# Altium schematic sheet ble_em9301.SchDoc
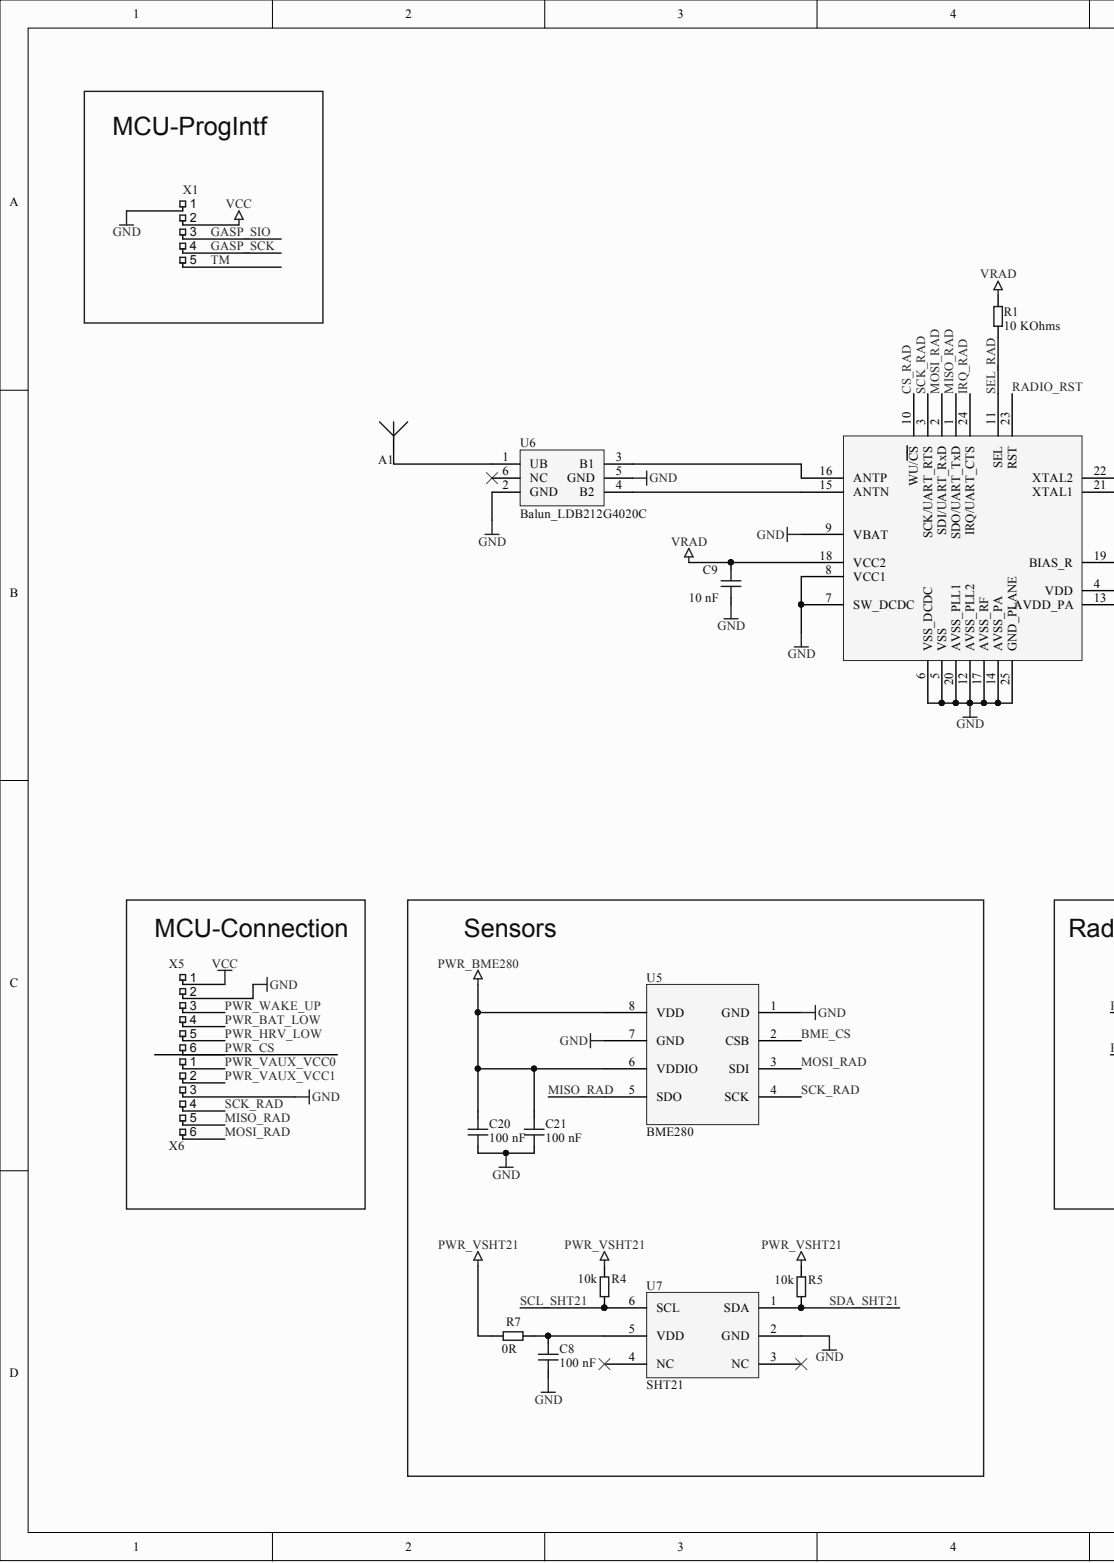
[diagram p0: ble_em9301.SchDoc, left]
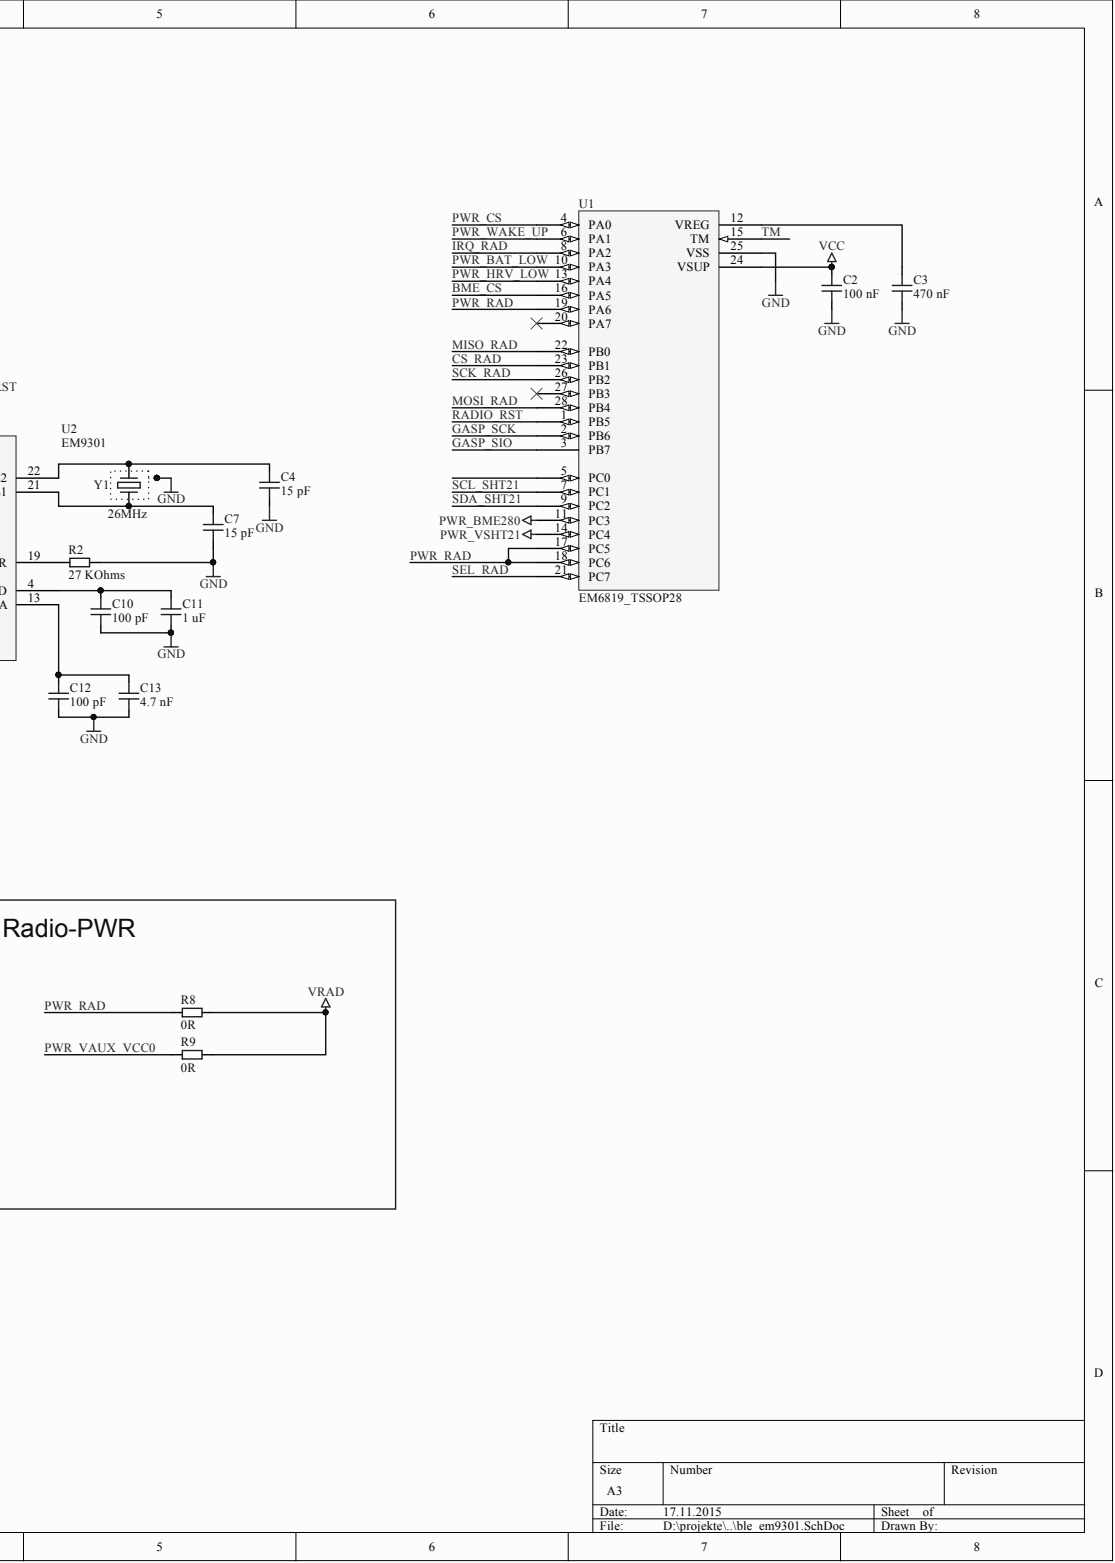
[diagram p1: ble_em9301.SchDoc, right]

## Parts
A1  Ant  [ANT AN2051]  (value not drawn)
C2  value 100 nF  [Chip_1005L]  (hidden comment: Cap)
C3  value 470 nF  [Chip_1005L]  (hidden comment: Cap)
C4  value 15 pF  [Chip_1005L]  (hidden comment: Cap)
C7  value 15 pF  [Chip_1005L]  (hidden comment: Cap)
C8  value 100 nF  [Chip_1005L]  (hidden comment: Cap)
C9  value 10 nF  [Chip_1005L]  (hidden comment: Cap)
C10  value 100 pF  [Chip_1005L]  (hidden comment: Cap)
C11  value 1 uF  [Chip_1005L]  (hidden comment: Cap)
C12  value 100 pF  [Chip_1005L]  (hidden comment: Cap)
C13  value 4.7 nF  [Chip_1005L]  (hidden comment: Cap)
C20  value 100 nF  [Chip_1005L]  (hidden comment: Cap)
C21  value 100 nF  [Chip_1005L]  (hidden comment: Cap)
R1  R  value 10 KOhms  [Chip_1005L]
R2  R  value 27 KOhms  [Chip_1005L]
R4  R  value 10k  [Chip_1005L]
R5  R  value 10k  [Chip_1005L]
R7  R  value 0R  [Chip_0603L]
R8  R  value 0R  [Chip_0603L]
R9  R  value 0R  [Chip_0603L]
U1  EM6819_TSSOP28  [TSSOP28]
U2  EM9301  [QFN-24_5x5]
U5  BME280  [SON65P250X94-8N]
U6  Balun_LDB212G4020C  [BALUN_0802]
U7  SHT21  [SHT21/x]
X1  TEST  [HEADER 1x5 50mil F]  (value not drawn)
X5  TEST  [HEADER 1x6 50mil]  (value not drawn)
X6  TEST  [HEADER 1x6 50mil]  (value not drawn)
Y1  value 26MHz  [XTAL ABM8]  (hidden comment: XTAL)

## Nets
GND: C2.1 C3.1 C4.1 C7.1 C8.1 C9.1 C10.1 C11.1 C12.1 C13.1 C20.1 C21.1 R2.2 U1.25(VSS) U2.5(VSS) U2.6(VSS_DCDC) U2.7(SW_DCDC) U2.8(VCC1) U2.9(VBAT) U2.12(AVSS_PLL2) U2.14(AVSS_PA) U2.17(AVSS_RF) U2.20(AVSS_PLL1) U2.25(GND_PLANE) U5.1(GND) U5.7(GND) U6.2(GND) U6.5(GND) U7.2(GND) X1.1 X5.2 X6.3 Y1.2 Y1.4
PWR_BME280: C20.2 C21.2 U1.11(PC3) U5.6(VDDIO) U5.8(VDD)
PWR_VSHT21: R4.2 R5.1 R7.1 U1.14(PC4)
VCC: C2.2 U1.24(VSUP) X1.2 X5.1
VRAD: C9.2 R1.2 R8.2 R9.2 U2.18(VCC2)
BME_CS: U1.16(PA5) U5.2(CSB)
CS_RAD: U1.23(PB1) U2.10(WU/CS)
GASP_SCK: U1.2(PB6) X1.4
GASP_SIO: U1.3(PB7) X1.3
IRQ_RAD: U1.8(PA2) U2.24(IRQ/UART_CTS)
MISO_RAD: U1.22(PB0) U2.1(SDO/UART_TxD) U5.5(SDO) X6.5
MOSI_RAD: U1.28(PB4) U2.2(SDI/UART_RxD) U5.3(SDI) X6.6
PWR_BAT_LOW: U1.10(PA3) X5.4
PWR_CS: U1.4(PA0) X5.6
PWR_HRV_LOW: U1.13(PA4) X5.5
PWR_RAD: R8.1 U1.17(PC5) U1.18(PC6) U1.19(PA6)
PWR_VAUX_VCC0: R9.1 X6.1
PWR_VAUX_VCC1: X6.2
PWR_WAKE_UP: U1.6(PA1) X5.3
RADIO_RST: U1.1(PB5) U2.23(RST)
SCK_RAD: U1.26(PB2) U2.3(SCK/UART_RTS) U5.4(SCK) X6.4
SCL_SHT21: R4.1 U1.7(PC1) U7.6(SCL)
SDA_SHT21: R5.2 U1.9(PC2) U7.1(SDA)
SEL_RAD: R1.1 U1.21(PC7) U2.11(SEL)
TM: U1.15(TM) X1.5
NetA1_1: A1.1 U6.1(UB)
NetC3_2: C3.2 U1.12(VREG)
NetC4_2: C4.2 U2.22(XTAL2) Y1.3
NetC7_2: C7.2 U2.21(XTAL1) Y1.1
NetC8_2: C8.2 R7.2 U7.5(VDD)
NetC10_2: C10.2 C11.2 U2.4(VDD)
NetC12_2: C12.2 C13.2 U2.13(AVDD_PA)
NetR2_1: R2.1 U2.19(BIAS_R)
NetU2_15: U2.15(ANTN) U6.4(B2)
NetU2_16: U2.16(ANTP) U6.3(B1)

Not connected: U1 5(PC0) 20(PA7) 27(PB3); U6 6(NC); U7 3(NC) 4(NC)

## Library data of the parts
A1 = Ant: Common Antenna Symbol; Category: Antenna; Component Kind: Standard; Library Name: newlib.SchLib
C2 C9 C21 = C: Capacitor; Manufacturer: Murata; Manufacturer Part Number: GRM155R61C104KA88J; Custom Description: Mehrschichtkeramik-Kondensatoren (MLCC) - SMD/SMT 0.1uF 16 volts 10%; Category: Mehrschichtkeramik-Kondensatoren (MLCC) - SMD/SMT; Component Kind: Standard; Supplier 1: Mouser; Supplier Part Number 1: 81-GRM155R61C104KA8J; Library Name: newlib.SchLib
C3 C11 = C: Capacitor; Manufacturer: Murata; Manufacturer Part Number: GRM155F51A474ZA01D; Custom Description: Mehrschichtkeramik-Kondensatoren (MLCC) - SMD/SMT 0.47uF 10Volts -20% to 80%; Category: Mehrschichtkeramik-Kondensatoren (MLCC) - SMD/SMT; Component Kind: Standard; Supplier 1: Mouser; Supplier Part Number 1: 81-GRM155F51A474ZA1D; Library Name: newlib.SchLib
C4 C7 = C: Capacitor; Manufacturer: Murata; Manufacturer Part Number: GRM1555C1H150GA01D; Custom Description: Mehrschichtkeramik-Kondensatoren (MLCC) - SMD/SMT 0402 15pF 50volts C0G 2%; Category: Mehrschichtkeramik-Kondensatoren (MLCC) - SMD/SMT; Component Kind: Standard; Supplier 1: Mouser; Supplier Part Number 1: 81-GRM1555C1H150GA1D; Library Name: newlib.SchLib
C8 C20 = C: Capacitor; Manufacturer: Murata; Manufacturer Part Number: GRM155R61C104KA88J; Custom Description: Mehrschichtkeramik-Kondensatoren (MLCC) - SMD/SMT 0.1uF 16 volts 10%; Value: 100 nF; Category: Mehrschichtkeramik-Kondensatoren (MLCC) - SMD/SMT; Component Kind: Standard; Supplier 1: Mouser; Supplier Part Number 1: 81-GRM155R61C104KA8J; Library Name: newlib.SchLib
C10 C12 = C: Capacitor; Manufacturer: Murata; Manufacturer Part Number: GRM1535C1H101JDD5D; Custom Description: Mehrschichtkeramik-Kondensatoren (MLCC) - SMD/SMT 100pF 50 volts 5%; Category: Mehrschichtkeramik-Kondensatoren (MLCC) - SMD/SMT; Component Kind: Standard; Supplier 1: Mouser; Supplier Part Number 1: 81-GRM1535C1H101JD5D; Library Name: newlib.SchLib
C13 = C: Capacitor; Manufacturer: Murata; Manufacturer Part Number: GRM155R61E472KA01D; Custom Description: Mehrschichtkeramik-Kondensatoren (MLCC) - SMD/SMT 0.0047uF 25volts 10%; Category: Mehrschichtkeramik-Kondensatoren (MLCC) - SMD/SMT; Component Kind: Standard; Supplier 1: Mouser; Supplier Part Number 1: 81-GRM155R61E472KA1D; Library Name: newlib.SchLib
R1 R4 R5 = R: Resistor; Manufacturer: Kamaya; Manufacturer Part Number: WR04X1002FTL; Custom Description: Dickfilmwiderstände - SMD 040210Kohms1%; Category: Dickfilmwiderstände - SMD; Component Kind: Standard; Supplier 1: Mouser; Supplier Part Number 1: 791-WR04X1002FTL; Library Name: newlib.SchLib
R2 = R: Resistor; Manufacturer: KOA Speer; Manufacturer Part Number: RK73B1ETTP273J; Custom Description: Dickfilmwiderstände - SMD 1/16watts 27Kohms 5%; Category: Dickfilmwiderstände - SMD; Component Kind: Standard; Supplier 1: Mouser; Supplier Part Number 1: 660-RK73B1ETTP273J; Library Name: newlib.SchLib
R7-R9 = R: Resistor; Manufacturer: KOA Speer; Manufacturer Part Number: RK73Z1ETTP; Custom Description: Dickfilmwiderstände - SMD ZEROohms JUMPER; Category: Dickfilmwiderstände - SMD; Component Kind: Standard; Supplier 1: Mouser; Supplier Part Number 1: 660-RK73Z1ETTP; Library Name: newlib.SchLib
U1 = EM6819_TSSOP28: EM6819 without DCDC; Manufacturer: EM Marin; Component Kind: Standard; Library Name: newlib.SchLib
U2 = EM9301: BLE 2.4 GHz Tranceiver; Manufacturer: EM Microelectronic; Category: 34 Treiber; Component Kind: Standard; Custom Description: BLE 2.4 GHz Tranceiver; Library Name: newlib.SchLib
U7 = SHT21: TempHumidSensor; Manufacturer: Sensirion; Manufacturer Part Number: SHT21, I2C; Category: Sensor; Component Kind: Standard; Custom Description: TempHumidSensor; Supplier 1: Farnell; Supplier Part Number 1: 1855468; Library Name: newlib.SchLib
X1 = CON 1x5: Connector 5 Pol; Category: Connector; Component Kind: Standard; Library Name: newlib.SchLib
X5 X6 = CON 1x6: Connector 6 Pol; Category: Connector; Component Kind: Standard; Library Name: newlib.SchLib
Y1 = XTAL 4P: 4 Pin XTAL; Manufacturer: ABRACON; Manufacturer Part Number: ABM8-26.000MHZ-10-1-U-T; Custom Description: Quarze +/-10PPM 26.0MHZ; Category: Quarze; Component Kind: Standard; Supplier 1: Mouser; Supplier Part Number 1: 815-ABM8-26-T; Library Name: newlib.SchLib
U5 = BME280: Component Kind: Standard; Library Name: Schlib1.SchLib
U6 = Balun_LDB212G4020C: Component Kind: Standard; Library Name: Schlib1.SchLib
